# Altium schematic sheet USB.SchDoc
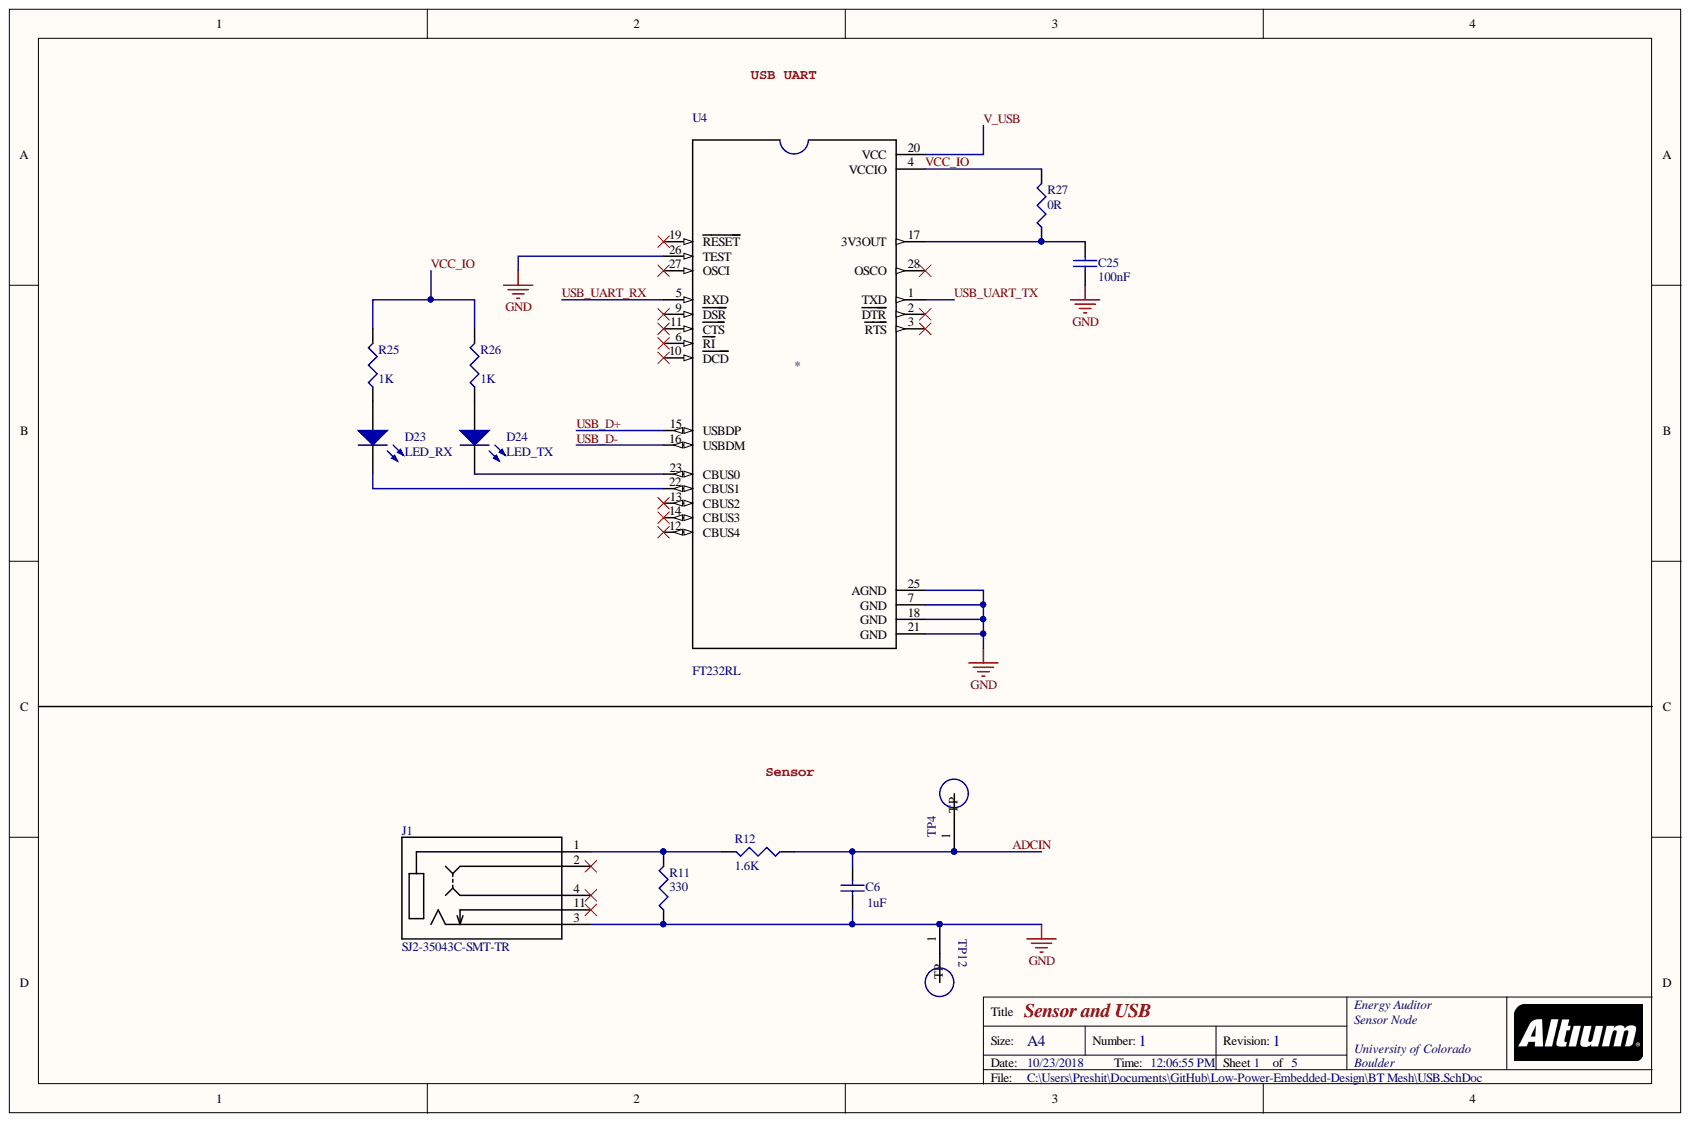
[diagram p0: USB.SchDoc]

## Parts
C6  1uF  [1608[0603]]
C25  100nF  [1608[0603]]
D23  LED_RX  [3.2X1.6X1.1]
D24  LED_TX  [3.2X1.6X1.1]
J1  SJ2-35043C-SMT-TR  [CUI_SJ2-35043C-SMT-TR]
R11  330  [1608[0603]]
R12  1.6K  [1608[0603]]
R25  1K  [1608[0603]]
R26  1K  [1608[0603]]
R27  0R  [1608[0603]]
TP4  5019  [TP380X203]  (value not drawn)
TP12  5019  [TP380X203]  (value not drawn)
U4  FT232RL  [SOP65P780X200-28N]

## Nets
GND: C6.1 C25.2 J1.3 R11.1 TP12.1 U4.7(GND) U4.18(GND) U4.21(GND) U4.25(AGND) U4.26(TEST)
ADCIN: C6.2 R12.1 TP4.1
USB_D+: U4.15(USBDP)
USB_D-: U4.16(USBDM)
USB_UART_RX: U4.5(RXD)
USB_UART_TX: U4.1(TXD)
V_USB: U4.20(VCC)
VCC_IO: R25.2 R26.2 R27.2 U4.4(VCCIO)
NetC25_1: C25.1 R27.1 U4.17(3V3OUT)
NetD23_1: D23.1 R25.1
NetD23_2: D23.2 U4.22(CBUS1)
NetD24_1: D24.1 R26.1
NetD24_2: D24.2 U4.23(CBUS0)
NetJ1_1: J1.1 R11.2 R12.2

Not connected: J1 2 4 11; U4 2(DTR) 3(RTS) 6(RI) 9(DSR) 10(DCD) 11(CTS) 12(CBUS4) 13(CBUS2) 14(CBUS3) 19(RESET) 27(OSCI) 28(OSCO)

## Library data of the parts
C6 = Cap: CAP CER 1UF 16V X7R 0603; Manufacturer: Samsung Electro-Mechanics; Manufacturer Part Number: CL10B105MO8NNWC; Component Kind: Standard; ComponentLink1Description: Digi-Key Link; ComponentLink1URL: https://www.digikey.com/product-detail/en/samsung-electro-mechanics/CL10B105MO8NNWC/1276-6524-1-ND/5961383; Digi-Key Part Number: 1276-6524-1-ND; Library Name: Miscellaneous Devices.IntLib; Signal Integrity: Cap; Simulation: CAP; Value: 1uF
C25 = Cap: CAP CER 0.1UF 16V X7R 0603; Manufacturer: AVX Corporation; Manufacturer Part Number: 0603YC104MAT4A; Component Kind: Standard; ComponentLink1Description: Digi-Key Link; ComponentLink1URL: https://www.digikey.com/products/en/capacitors/ceramic-capacitors/60?k=capacitor&k=&pkeyword=capacitor&pv2049=u0.1%C2%B5F&sf=0&FV=c0005%2C1c0002%2C380009%2C400005%2C1f140000%2Cffe0003c&quantity=&ColumnSort=0&page=1&stock=1&pageSize=25; Digi-Key Part Number: 478-7617-1-ND; Library Name: Miscellaneous Devices.IntLib; Signal Integrity: Cap; Simulation: CAP; Value: 100nF
D23 = LED2: LED RED DIFFUSED 0603 SMD; Manufacturer: OSRAM Opto Semiconductors Inc.; Manufacturer Part Number: LS L29K-H1J2-1-Z; Component Kind: Standard; ComponentLink1Description: Digi-Key Link; ComponentLink1URL: https://www.digikey.com/product-detail/en/osram-opto-semiconductors-inc/LG-L29K-F2J1-24-Z/475-3118-1-ND/4899549; Digi-Key Part Number: 475-1195-1-ND; Library Name: Miscellaneous Devices.IntLib; Simulation: LED2
D24 = LED2: LED YELLOW DIFFUSED 0603 SMD; Manufacturer: OSRAM Opto Semiconductors Inc.; Manufacturer Part Number: LY L29K-H1K2-26-Z; Component Kind: Standard; ComponentLink1Description: Digi-Key Link; ComponentLink1URL: https://www.digikey.com/product-detail/en/osram-opto-semiconductors-inc/LY-L29K-H1K2-26-Z/475-1196-1-ND/810357; Digi-Key Part Number: 475-1196-1-ND; Library Name: Miscellaneous Devices.IntLib; Simulation: LED2
J1 = SJ2-35043C-SMT-TR: AUDIO JACK, 3.5 MM, RT, 4 CONDUC; Manufacturer: CUI Inc.; Component Kind: Standard; ComponentLink1Description: Digi-Key Link; ComponentLink1URL: https://www.digikey.com/products/en/connectors-interconnects/barrel-audio-connectors/434?k=SJ2-35043C-SMT-TR&k=&pkeyword=SJ2-35043C-SMT-TR&pv7=2&sf=0&quantity=&ColumnSort=0&page=1&pageSize=25; Digi-Key Part Number: CP-SJ2-35043C-SMT-CT-ND; Library Name: SJ2-35043C-SMT-TR.SchLib; Manufacturer Part Number: SJ2-35043C-SMT-TR
R11 = Res3: RES SMD 330 OHM 5% 1/10W 0603; Manufacturer: Vishay Dale; Manufacturer Part Number: CRCW0603330RJNEAC; Component Kind: Standard; ComponentLink1Description: Digi-Key Link; ComponentLink1URL: https://www.digikey.com/product-detail/en/vishay-dale/CRCW0603330RJNEAC/541-4060-1-ND/7928743; Digi-Key Part Number: 541-4060-1-ND; Library Name: Miscellaneous Devices.IntLib; Signal Integrity: Res; Simulation: RESISTOR; Value: 330
R12 = Res3: RES SMD 1.6K OHM 5% 1/10W 0603; Manufacturer: Yageo; Manufacturer Part Number: RC0603JR-071K6L; Component Kind: Standard; ComponentLink1Description: Digi-Key Link; ComponentLink1URL: https://www.digikey.com/product-detail/en/yageo/RC0603JR-071K6L/311-1.6KGRCT-ND/729639; Digi-Key Part Number: 311-1.6KGRCT-ND; Library Name: Miscellaneous Devices.IntLib; Signal Integrity: Res; Simulation: RESISTOR; Value: 1.6K
R25 R26 = Res3: RES SMD 1K OHM 0.1% 1/10W 0603; Manufacturer: Yageo; Manufacturer Part Number: RT0603BRD071KL; Component Kind: Standard; ComponentLink1Description: Digi-Key Link; ComponentLink1URL: https://www.digikey.com/product-detail/en/yageo/RT0603BRD071KL/YAG1237CT-ND/4340590; Digi-Key Part Number: YAG1237CT-ND; Library Name: Miscellaneous Devices.IntLib; Signal Integrity: Res; Simulation: RESISTOR; Value: 1K
R27 = Res3: RES 0 OHM JUMPER 1/8W 0603; Manufacturer: Vishay Beyschlag; Manufacturer Part Number: MCT0603MZ0000ZP500; Component Kind: Standard; ComponentLink1Description: Digi-Key Link; ComponentLink1URL: https://www.digikey.com/product-detail/en/vishay-beyschlag/MCT0603MZ0000ZP500/749-1745-1-ND/7348053; Digi-Key Part Number: 749-1745-1-ND; Library Name: Miscellaneous Devices.IntLib; Signal Integrity: Res; Simulation: RESISTOR; Value: 0R
TP4 TP12 = 5019: Phosphor Bronze Contact Miniature Silver Plated Surface Mount Test Points; Manufacturer: Keystone; Component Kind: Standard; ComponentLink1Description: Digi-Key Link; ComponentLink1URL: https://www.digikey.com/product-detail/en/keystone-electronics/5019/36-5019CT-ND/3907344?utm_source=snapeda&utm_medium=aggregator&utm_campaign=symbol; Digi-Key Part Number: 36-5019CT-ND; Library Name: 5019.IntLib; Manufacturer Part Number: 5019
U4 = FT232RL-REEL: USB Bridge, USB to UART USB 2.0 UART Interface 28-SSOP; Manufacturer: FTDI, Future Technology Devices International Ltd; Component Kind: Standard; ComponentLink1Description: Digi-Key Link; ComponentLink1URL: https://www.digikey.com/product-detail/en/ftdi-future-technology-devices-international-ltd/FT232RL-REEL/768-1007-1-ND/1836402; Digi-Key Part Number: 768-1007-1-ND; Library Name: FT232RL-REEL.IntLib; Manufacturer Part Number: FT232RL-REEL
